# Altium schematic sheet Deck.SchDoc(Deck)
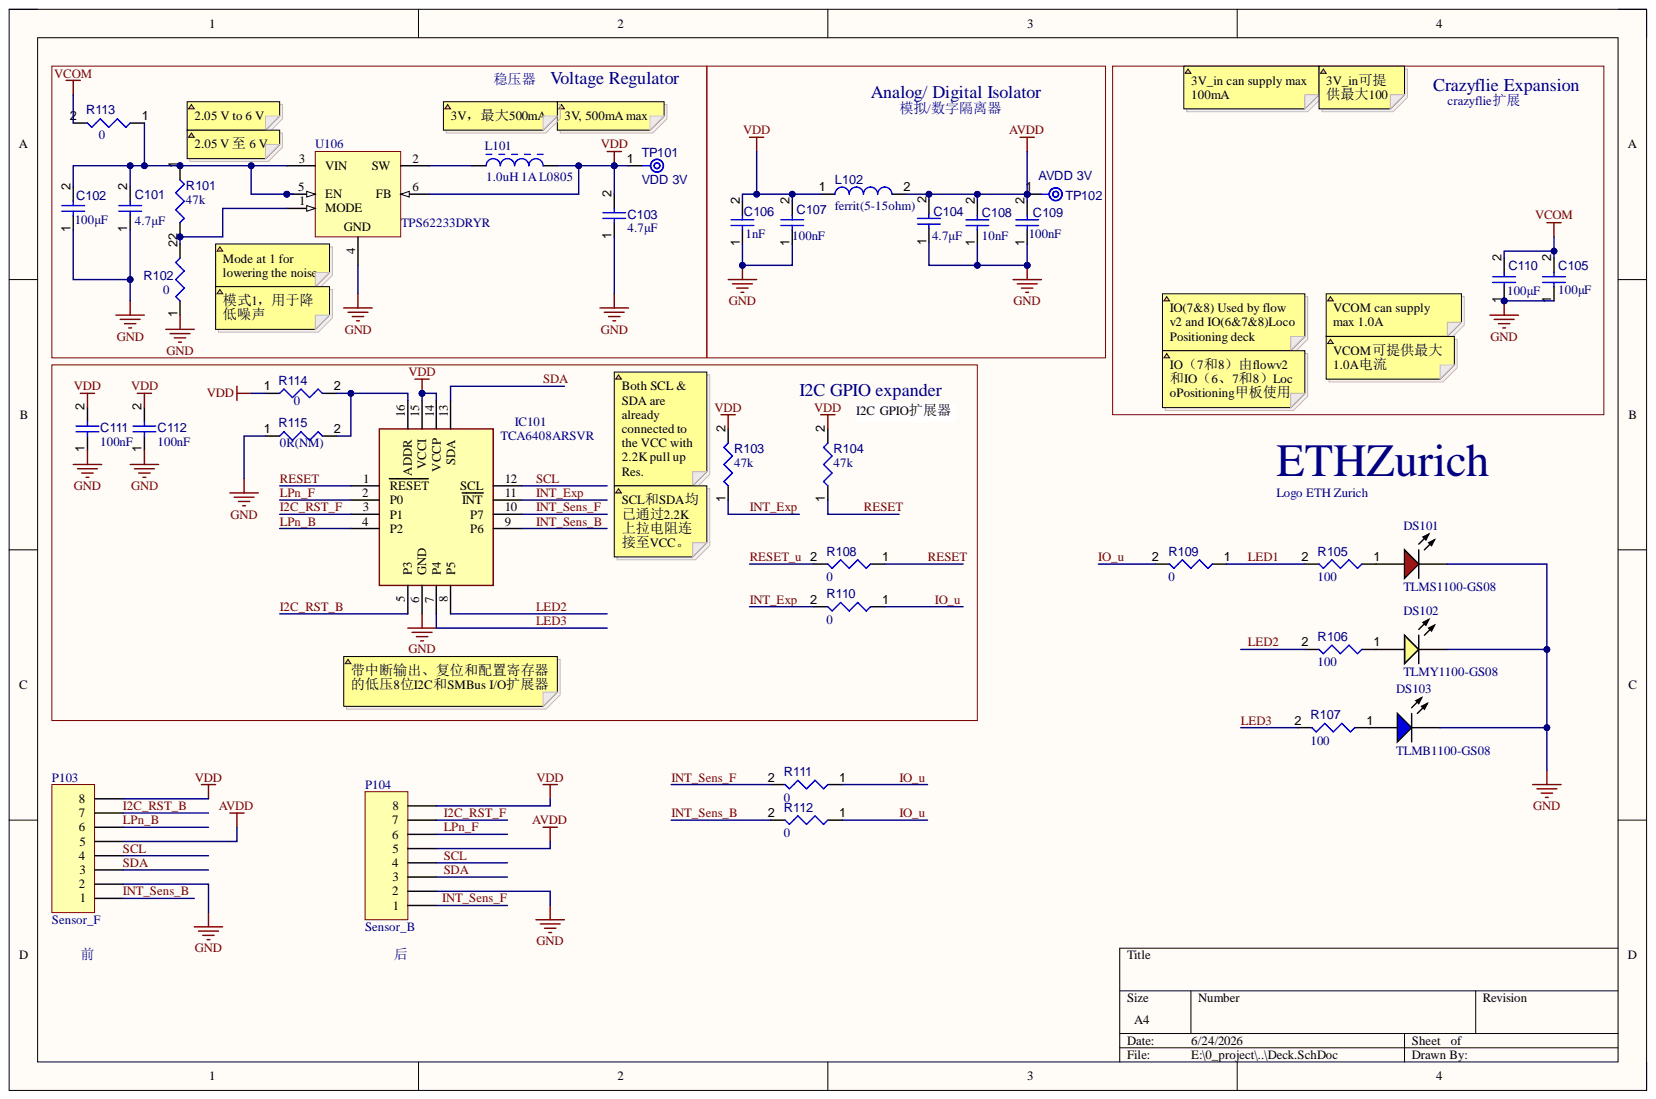
[diagram p0: Deck.SchDoc]

## Parts
A?  Logo ETH Zurich  [eth-zurich_3mm]  (no BOM)
C101  value 4.7μF  [CAPC1005X55X25ML5T10]  (hidden comment: Capacitor 1 uF +/- 10% 6.3 V 1005)
C102  value 100μF  [CAPC0805(2012)145_L]  (hidden comment: Capacitor 100μF +/-20% 6.3V 0805)
C103  value 4.7μF  [CAPC1005X55X25ML5T10]  (hidden comment: Capacitor 1 uF +/- 10% 6.3 V 1005)
C104  value 4.7μF  [CAPC1005X55X25ML5T10]  (hidden comment: Capacitor 1 uF +/- 10% 6.3 V 1005)
C105  value 100μF  [CAPC0805(2012)145_L]  (hidden comment: Capacitor 100μF +/-20% 6.3V 0805)
C106  value 1nF  [CAPC0402(1005)60_L]  (hidden comment: Capacitor 100nF +/-20% 25V 0402)
C107  value 100nF  [CAPC0402(1005)60_L]  (hidden comment: Capacitor 100nF +/-20% 25V 0402)
C108  value 10nF  [CAPC0402(1005)60_L]  (hidden comment: Capacitor 100nF +/-20% 25V 0402)
C109  value 100nF  [CAPC0402(1005)60_L]  (hidden comment: Capacitor 100nF +/-20% 25V 0402)
C110  value 100μF  [CAPC0805(2012)145_L]  (hidden comment: Capacitor 100μF +/-20% 6.3V 0805)
C111  value 100nF  [CAPC0402(1005)60_L]  (hidden comment: Capacitor 100nF +/-20% 25V 0402)
C112  value 100nF  [CAPC0402(1005)60_L]  (hidden comment: Capacitor 100nF +/-20% 25V 0402)
DS101  TLMS1100-GS08  [VISH-TLMX1100_V]
DS102  TLMY1100-GS08  [VISH-TLMX1100-2_V]
DS103  TLMB1100-GS08  [VISH-TLMX1100-2_V]
IC101  TCA6408ARSVR  [QFN40P180X260X55-16N]
L101  1.0uH 1A L0805  [INDC2013X105X50ML20T20]
L102  value ferrit(5-15ohm)  [MURA-LQP15MN3N6B02D-2_M]  (hidden comment: LQP15MN3N6B02D 3.6nH)
P103  Sensor_F  [PCBComponent_1]
P104  Sensor_B  [PCBComponent_1]
R101  value 47k  [RESC0402(1005)_L]  (hidden comment: Resistor 47K5 +/-1 % 0402 63 mW)
R102  value 0  [RESC0402(1005)_L]  (hidden comment: Jumper 0402)
R103  value 47k  [RESC0402(1005)_L]  (hidden comment: Resistor 47K5 +/-1 % 0402 63 mW)
R104  value 47k  [RESC0402(1005)_L]  (hidden comment: Resistor 47K5 +/-1 % 0402 63 mW)
R105  value 100  [RESC0402(1005)_L]  (hidden comment: Resistor 47K5 +/-1 % 0402 63 mW)
R106  value 100  [RESC0402(1005)_L]  (hidden comment: Resistor 47K5 +/-1 % 0402 63 mW)
R107  value 100  [RESC0402(1005)_L]  (hidden comment: Resistor 47K5 +/-1 % 0402 63 mW)
R108  value 0  [RESC0402(1005)_L]  (hidden comment: Jumper 0402)
R109  value 0  [RESC0402(1005)_L]  (hidden comment: Jumper 0402)
R110  value 0  [RESC0402(1005)_L]  (hidden comment: Jumper 0402)
R111  value 0  [RESC0402(1005)_L]  (hidden comment: Jumper 0402)
R112  value 0  [RESC0402(1005)_L]  (hidden comment: Jumper 0402)
R113  value 0  [RESC0402(1005)_L]  (hidden comment: Jumper 0402)
R114  value 0  [RESC0402(1005)_L]  (hidden comment: Jumper 0402)
R115  value 0R(NM)  [RESC0402(1005)_L]  (hidden comment: Jumper 0402)
TP101  VDD 3V  [TP06R]  (no BOM)
TP102  AVDD 3V  [TP06R]  (no BOM)
U106  TPS62233DRYR  [DRY0006A]

## Nets
AVDD: C104.2 C108.2 C109.2 L102.2 P103.5 P104.5 TP102.1
GND: C101.1 C102.1 C103.1 C104.1 C105.1 C106.1 C107.1 C108.1 C109.1 C110.1 C111.1 C112.1 DS101.2 DS102.2 DS103.2 IC101.6(GND) P103.2 P104.2 R102.1 R115.1 U106.4
VCOM: C105.2 C110.2 R113.2
VDD: C103.2 C106.2 C107.2 C111.2 C112.2 IC101.14(VCCP) IC101.15(VCCI) L101.2 L102.1 P103.8 P104.8 R103.2 R104.2 R114.1 TP101.1 U106.6(FB)
~{INT_Exp}: IC101.11(INT) R103.1 R110.2
~{RESET}: IC101.1(RESET) R104.1 R108.1
~{RESET_u}: R108.2
I2C_RST_B: IC101.5(P3) P103.7
I2C_RST_F: IC101.3(P1) P104.7
INT_Sens_B: IC101.9(P6) P103.1 R112.2
INT_Sens_F: IC101.10(P7) P104.1 R111.2
IO_u: R109.2 R110.1 R111.1 R112.1
LED1: R105.2 R109.1
LED2: IC101.8(P5) R106.2
LED3: IC101.7(P4) R107.2
LPn_B: IC101.4(P2) P103.6
LPn_F: IC101.2(P0) P104.6
SCL: IC101.12(SCL) P103.4 P104.4
SDA: IC101.13(SDA) P103.3 P104.3
NetC101_2: C101.2 C102.2 R101.1 R113.1 U106.3(VIN) U106.5(EN)
NetDS101_1: DS101.1 R105.1
NetDS102_1: DS102.1 R106.1
NetDS103_1: DS103.1 R107.1
NetIC101_16: IC101.16(ADDR) R114.2 R115.2
NetL101_1: L101.1 U106.2(SW)
NetR101_2: R101.2 R102.2 U106.1(MODE)

## Library data of the parts
A? = CMP-00038-1: Library Name: Sensor_Node_Shield.IntLib
C101 C103 C104 = CMP-001-00009-6: Chip Capacitor, 1 uF, +/- 10%, 6.3 V, 1005 (0402 Metric); Capacitor Type: Ceramic; Voltage Rating: 6.3V; Case/Package: 0402; Component Kind: Standard; Max Operating Temperature: 85°C; Min Operating Temperature: -55°C; Mounting Technology: SM; Pins: 2; RoHS Compliant: True; Tolerance: 10%; Library Name: ETH Zurich
C102 C105 C110 = CMP-001-00054-4: Chip Capacitor, 100μF +/-20%, 6.3V, 0805, Thickness 1.45 mm; Tolerance: 10%; Case/Package: 0805; Component Kind: Standard; Max Operating Temperature: 85°C; Min Operating Temperature: -55°C; Mounting Technology: SMT; Pins: 2; Voltage Rating: 6.3V; Library Name: ETH Zurich
C106-C109 C111 C112 = CMP-001-00065-4: Chip Capacitor, 100nF +/-20%, 25V, 0402, Thickness 0.6 mm; Tolerance: 10%; Case/Package: 0402; Component Kind: Standard; Max Operating Temperature: 85°C; Min Operating Temperature: -55°C; Mounting Technology: SMT; Pins: 2; Voltage Rating: 25V; Library Name: ETH Zurich
DS101 = CMP-007-00030-2: Red Led, 20mA, 2.1 V, -40 to 100 degC, 2-Pin SMD (0603), RoHS, Tape and Reel; Manufacturer: Vishay Semiconductor; Forward Voltage: 2.1V; Lens Style: Clear; Luminous Intensity: 63mcd; Power: 90mW; Viewing Angle: 160degrees; Case/Package: 0603; Colour: Red; Component Kind: Standard; Datasheet URL: http://www.vishay.com/docs/83173/tlmb1100.pdf; Datasheet Version: Rev. 2.7, 03/2016; Forward Current: 20mA; Illumination Colour: Red; Manufacturer URL: http://www.vishay.com/; Max Operating Temperature: 100°C; Min Operating Temperature: -40°C; Mounting Technology: SM; Nominal Vf: 2.1V; Package Description: 2-Pin Surface Mount Device, Body 1.6 x 0.8 mm; Package Quantity: 3000; Package Reference: TLMX1100; Packaging: TapeandReel; Pins: 2; RoHS Compliant: True; Library Name: ETH Zurich
DS102 = CMP-007-00031-2: Yellow LED, 20 mA, 2.1 V, -40 to 100 degC, 2-Pin SMD (0603), RoHS, Tape and Reel; Manufacturer: Vishay Semiconductor; Forward Current: 20mA; Forward Voltage: 2.1V; Lens Style: Diffused; Luminous Intensity: 80mcd; Power: 90mW; Viewing Angle: 160degrees; Case/Package: 0603; Colour: Yellow; Component Kind: Standard; Datasheet URL: http://download.siliconexpert.com/pdfs/2016/8/7/12/43/26/667/vsh_/manual/379263299541529tlmb1100.pdf; Datasheet Version: Rev. 2.7, 03/2016; Illumination Colour: Yellow; Manufacturer URL: http://www.vishay.com/; Max Operating Temperature: 100°C; Min Operating Temperature: -40°C; Mounting Technology: SM; Nominal Vf: 2.1V; Package Description: 2-Pin Surface Mount Device, Body 1.6 x 0.8 mm; Package Quantity: 3000; Package Reference: 0603; Packaging: TapeandReel; Pins: 2; RoHS Compliant: True; Library Name: ETH Zurich
DS103 = CMP-007-00028-2: Blue LED, 10 mA, 3.9 V, -40 to 100 degC, 2-Pin SMD (0603), RoHS, Tape and Reel; Manufacturer: Vishay Semiconductor; Forward Current: 10mA; Forward Voltage: 3.9V; Lens Style: Diffused; Luminous Intensity: 5mcd; Power: 68mW; Viewing Angle: 160degrees; Case/Package: 0603; Colour: Blue; Component Kind: Standard; Datasheet URL: http://download.siliconexpert.com/pdfs/2016/8/7/12/43/26/667/vsh_/manual/379263299541529tlmb1100.pdf; Datasheet Version: Rev. 2.7, 03/2016; Illumination Colour: Blue; Manufacturer URL: http://www.vishay.com/; Max Operating Temperature: 100°C; Min Operating Temperature: -40°C; Mounting Technology: SM; Nominal Vf: 3.9V; Package Description: 2-Pin Surface Mount Device, Body 1.6 x 0.8 mm; Package Quantity: 3000; Package Reference: 0603; Packaging: TapeandReel; Pins: 2; RoHS Compliant: True; Library Name: ETH Zurich
IC101 = TCA6408ARSVR: Integrated Circuit; Manufacturer_Name: Texas Instruments; Arrow Part Number: TCA6408ARSVR; Arrow Price/Stock: https://www.arrow.com/en/products/tca6408arsvr/texas-instruments?region=nac; Component Kind: Standard; Datasheet Link: http://www.ti.com/lit/gpn/tca6408a; Height: 0.55mm; Manufacturer_Part_Number: TCA6408ARSVR; Mouser Part Number: 595-TCA6408ARSVR; Mouser Price/Stock: https://www.mouser.co.uk/ProductDetail/Texas-Instruments/TCA6408ARSVR?qs=AFkNxQkJKAISZm6jGsM%252BSQ%3D%3D
L101 = CMP-006-00000-3: 1.0uH 1150mA; Manufacturer: Murata; Core Material: Ferrite; Current Rating: 1.5A; Impedance: 330Ohm; Resistance: 90mohm; Tolerance: 25%; Case/Package: 0805; Component Kind: Standard; Datasheet URL: http://psearch.en.murata.com/inductor/product/LQG15HS15NJ02_.pdf; Datasheet Version: Nov-11; Max Current Rating: 1.5A; Max Operating Temperature: 125°C; Min Operating Temperature: -55°C; Mounting Technology: SM; Package Description: 2-Pin Surface Mount Device, Body 2 x1.25 mm; Package Quantity: 4000; Package Reference: 0805; Packaging: TapeandReel; RoHS Compliant: True; Library Name: ETH Zurich
L102 = CMP-006-00010-6: Inductor, 500 MHz, 500 mA, 5 Ohm, -40 to 85 degC, 01005 (0402 Metric),; Manufacturer: Murata; Core Material: Ferrite; Current Rating: 170mA; Q Factor: 13; Self Resonant Frequency: 6GHz; Shielding: Unshielded; Case/Package: 0402; Component Kind: Standard; Datasheet URL: http://download.siliconexpert.com/pdfs/2016/4/21/8/25/31/646/mrt_/manual/797549851250188lqp15mn3n6b0223.pdf; Datasheet Version: Apr-16; Max Operating Temperature: 85°C; Min Operating Temperature: -40°C; Mounting Technology: SM; Package Description: 2-Pin Surface Mount Device, Body 1 x 0.5 mm; Package Quantity: 10000; Package Reference: 0402; Packaging: TapeandReel; RoHS Compliant: True; Library Name: ETH Zurich
P103 P104 = MHDR1X8: Header, 8-Pin; System: Connector; Component Kind: Standard; LatestRevisionDate: 17-Jul-2002; LatestRevisionNote: Re-released for DXP Platform.; Library Name: Miscellaneous Connectors.IntLib; Signal Integrity: Connector; Publisher: Altium Limited
R101 R103-R107 = CMP-009-00137-3: Chip Resistor, 47,5 kOhms, +/-1 %, 63 mW, -55 to 155 degC, 0402; Power: 63mW; Tolerance: 1%; Case/Package: 0402; Component Kind: Standard; Max Operating Temperature: 155°C; Min Operating Temperature: -55°C; Pins: 2; Library Name: ETH Zurich
R102 R108-R112 R114 R115 = CMP-009-00148-3: Case/Package: 0402; Component Kind: Standard; Description: Jumper 0402; Max Operating Temperature: 155°C; Min Operating Temperature: -55°C; Pins: 2; Library Name: ETH Zurich
R113 = CMP-009-00148-3: Current sensing jumper OR Fuse; Case/Package: 0402; Component Kind: Standard; Max Operating Temperature: 155°C; Min Operating Temperature: -55°C; Pins: 2; Library Name: ETH Zurich
TP101 TP102 = CMP-00028-00002-2: 0.6mm round; Pins: 1; Library Name: ETH Zurich
U106 = CMP-0323-00281-3: Buck Step Down Regulator with 2.05 to 6 V Input and 3 V Output, -40 to 85 degC, 6-Pin SON (DRY), Green (RoHS & no Sb/Br); Manufacturer: Texas Instruments; Duty Cycle(Max)(%): 100; Iout(Max)(A): 0.5; Iq(Typ)(mA): 0.022; Preset Vout(V): 3; Rating: Catalog; Regulated Outputs(#): 1; SubFamily: Step-Down Regulator; Switch Current Limit(Typ)(A): 0.84; Synchronous Rectification: False; Vin(Max)(V): 6; Vin(Min)(V): 2.05; Component Kind: Standard; ComponentLink1Description: Datasheet; ComponentLink1URL: http://www.ti.com/general/docs/lit/getliterature.tsp?genericPartNumber=TPS62233&fileType=pdf; ComponentLink2Description: Package Specification; ComponentLink2URL: http://www.ti.com/litv/pdf/mpds221e; ComponentLink3Description: URL Link1; ComponentLink3URL: Samples URL:http://www.ti.com/product/tps62233#samples; DatasheetVersion: SLVS941E; Eco-Plan: Green (RoHS & no Sb/Br); Library Name: Altium Content Vault; Mounting Technology: Surface Mount; PackageDescription: 6-Pin Plastic Small Outline No-Lead, 1 x 1.45 x 0.6 mm Body, 0.5 mm Pitch; PackageReference: DRY0006A; PackageVersion: revF, Dec-2011; PartNumber: TPS62233DRYR; Topology: Buck; Vout(Max)(V): 3; Vout(Min)(V): 3
